# Altium schematic sheet MCU.SchDoc
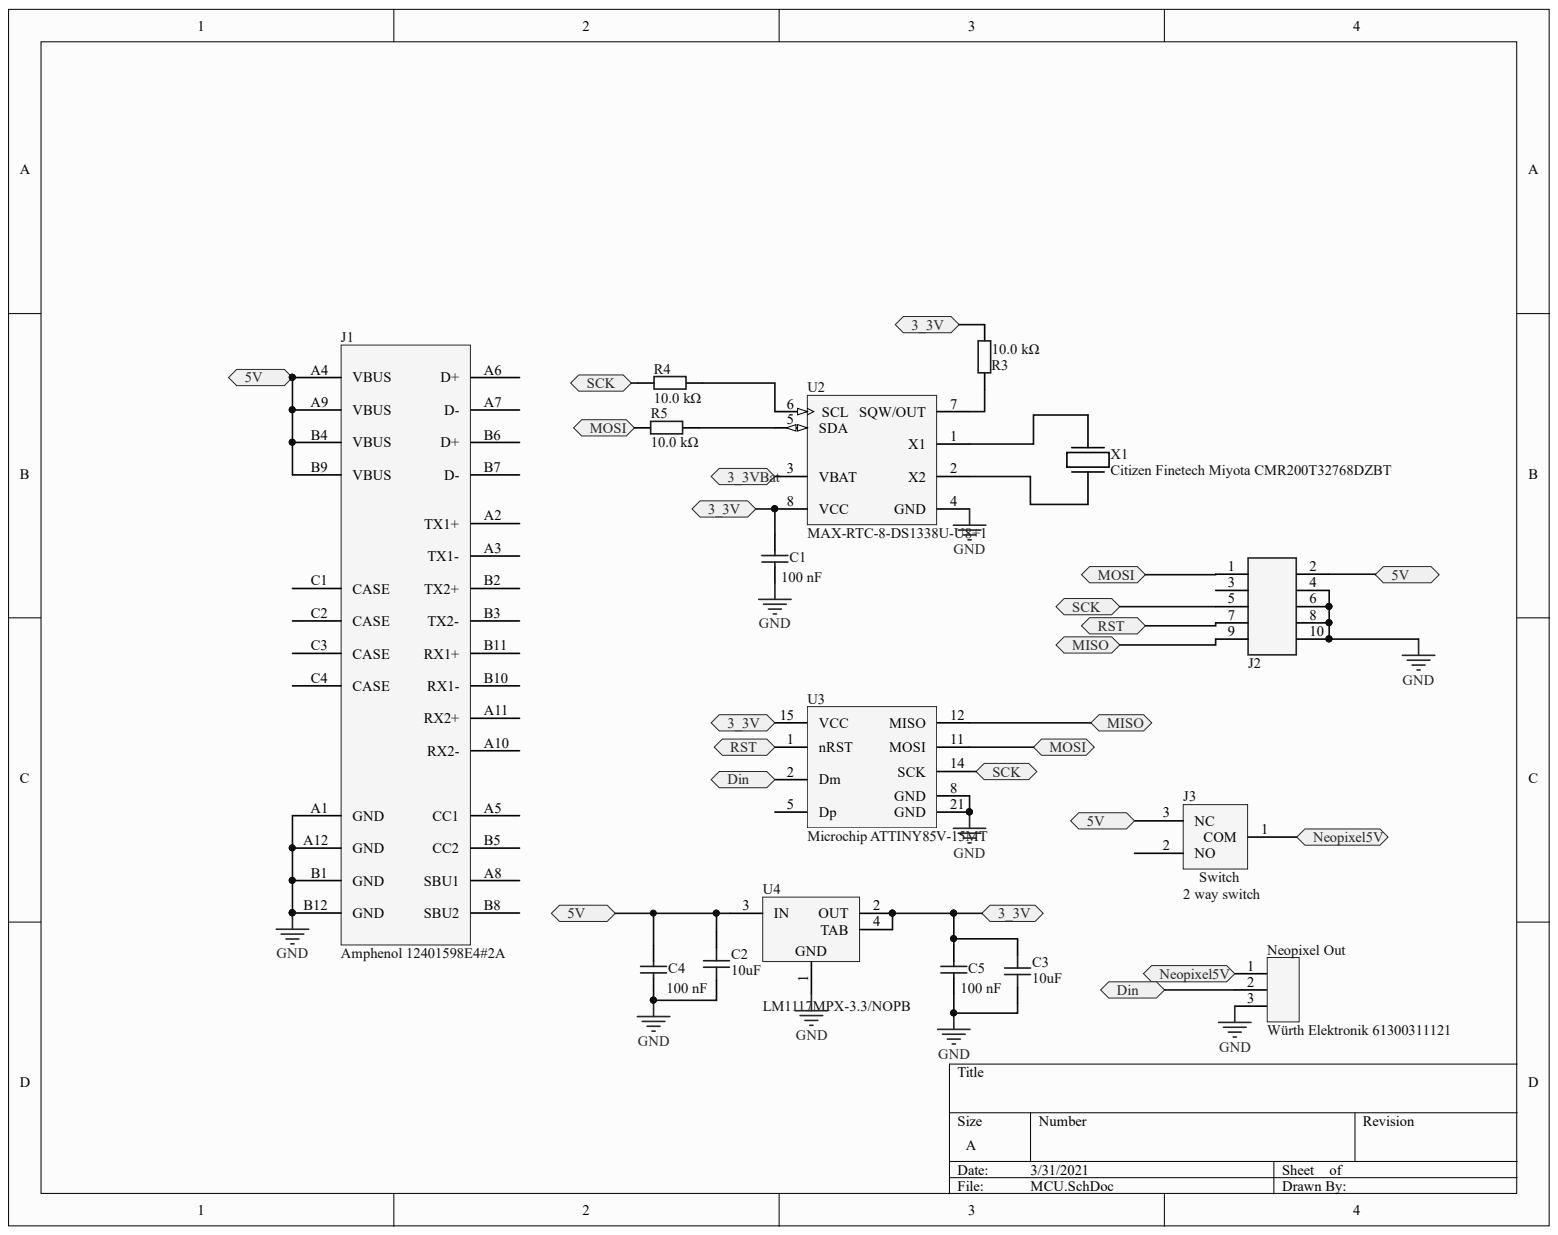
[diagram p0: MCU.SchDoc]

## Parts
C1  Capacitance: 100 nF  [PCB-eewx94glvk4bhx4pifal-1]  (hidden comment: CL05F104ZO5NNNC)
C2  10uF  [C0603]
C3  10uF  [C0603]
C4  CL05F104ZO5NNNC  [PCB-eewx94glvk4bhx4pifal-1]  (value not drawn)
C5  CL05F104ZO5NNNC  [PCB-eewx94glvk4bhx4pifal-1]  (value not drawn)
J1  Amphenol 12401598E4#2A  Manufacturer Part Number: 12401598E4#2A  [PCB-o51ryed2xyiiyzdqukpx-1]
J2  Connecteur_HE10_2X5  [PCB-vpkch8h1uqdxqvynfy4j-1]  (value not drawn)
J3  2 way switch  [PCB-z2mqghhu0j8lc0kix43w-1]
Neopixel_Out  Würth Elektronik 61300311121  [PCB-s0hfil65plaomi4ibvcw-1]
R3  Res2  [PCB-c90638nymr1sxtgb7n4t-1]  (value not drawn)
R4  Resistance: 10.0 kΩ  [PCB-c90638nymr1sxtgb7n4t-1]  (hidden comment: Res2)
R5  Resistance: 10.0 kΩ  [PCB-c90638nymr1sxtgb7n4t-1]  (hidden comment: Res2)
U2  MAX-RTC-8-DS1338U-U8+1  [U8+1_N]
U3  Microchip ATTINY85V-15MT  [PCBComponent_1 - duplicate]
U4  LM1117MPX-3.3/NOPB  [MP04A_L]
X1  Citizen Finetech Miyota CMR200T32768DZBT  [PCB-82rgjdkj2ta51ccq55iy-1]

## Ports
3_3V, 3_3VBAT, 5V, DIN, MISO, MOSI, NEOPIXEL5V, RST, SCK

## Nets
3_3VBAT: U2.3(VBAT) ; port 3_3VBAT
DIN: Neopixel_Out.2 U3.2(Dm) ; port DIN
GND: C1.1 C2.1 C3.1 C4.1 C5.1 J1.A1(GND) J1.A12(GND) J1.B1(GND) J1.B12(GND) J2.4 J2.6 J2.8 J2.10 Neopixel_Out.3 U2.4(GND) U3.8(GND) U3.21(GND) U4.1
NEOPIXEL5V: J3.1 Neopixel_Out.1 ; port NEOPIXEL5V
3_3V: C1.2 C3.2 C5.2 R3.2 U2.8(VCC) U3.15(VCC) U4.2(OUT) U4.4(TAB) ; port 3_3V
5V: C2.2 C4.2 J1.A4(VBUS) J1.A9(VBUS) J1.B4(VBUS) J1.B9(VBUS) J2.2 J3.3 U4.3(IN) ; port 5V
MISO: J2.9 U3.12(MISO) ; port MISO
MOSI: J2.1 R5.2 U3.11(MOSI) ; port MOSI
RST: J2.7 U3.1(nRST) ; port RST
SCK: J2.5 R4.2 U3.14(SCK) ; port SCK
NetR3_1: R3.1 U2.7(SQW/OUT)
NetR4_1: R4.1 U2.6(SCL)
NetR5_1: R5.1 U2.5(SDA)
NetU2_1: U2.1(X1) X1.2
NetU2_2: U2.2(X2) X1.1

Not connected: J1 A2(TX1+) A3(TX1-) A5(CC1) A6(D+) A7(D-) A8(SBU1) A10(RX2-) A11(RX2+) B2(TX2+) B3(TX2-) B5(CC2) B6(D+) B7(D-) B8(SBU2) B10(RX1-) B11(RX1+) C1(CASE) C2(CASE) C3(CASE) C4(CASE); J2 3; J3 2; U3 5(Dp)

## Library data of the parts
C1 = CMP-7737beb0b932a8f0-3: Cap Ceramic 0.1uF 16V Y5V -20% to 80% SMD 0402 85°C Paper T/R; Capacitance Tolerance: +80/-20%; Lead-Free Status: Lead Free; Voltage Rating (DC): 16.0 V; Case/Package: 0402; Component Kind: Standard; Dielectric Characteristic: Y5V; Library Name: CommunityVault; Manufacturer Part Number: CL05F104ZO5NNNC; OctopartID: 213ce849cbe4fefb; Octopart URL: https://octopart.com/cl05f104zo5nnnc-samsung-8376254; RoHS: Compliant
C2 C3 = CMP-2000ea615e44ffc7-5: Multilayer Ceramic Capacitors MLCC - SMD/SMT 0603 10uF 10volts *Derate Voltage/Temp; Manufacturer: Murata Electronics; Manufacturer Part Number: GRM188R61A106KE69J; Capacitance: 10.0 µF; Case/Package (SI): 1608; Dielectric Characteristic: X5R; Lead-Free Status: Lead Free; Voltage Rating (DC): 10.0 V; Case/Package: 0603, 1608; Category: Multilayer Ceramic Capacitors MLCC - SMD/SMT; Component Kind: Standard; Library Name: CommunityVault; Lifecycle Status: Active; OctopartID: 2000ea615e44ffc7; Octopart URL: https://octopart.com/grm188r61a106ke69j-murata-57367330; Package / Case: 0603 (1608 metric); RoHS: Compliant; Size-Thickness: 800 µm; Size-Width: 800 µm; Supplier 1: Mouser; Supplier Part Number 1: 81-GRM188R61A106KE9J
C4 C5 = CMP-7737beb0b932a8f0-3: Cap Ceramic 0.1uF 16V Y5V -20% to 80% SMD 0402 85°C Paper T/R; Capacitance: 100 nF; Capacitance Tolerance: +80/-20%; Lead-Free Status: Lead Free; Voltage Rating (DC): 16.0 V; Case/Package: 0402; Component Kind: Standard; Dielectric Characteristic: Y5V; Library Name: CommunityVault; Manufacturer Part Number: CL05F104ZO5NNNC; OctopartID: 213ce849cbe4fefb; Octopart URL: https://octopart.com/cl05f104zo5nnnc-samsung-8376254; RoHS: Compliant
J1 = CMP-1e79d211525dc1be-1: USB 3.1 Gen 2 Type C Receptacle Right Angle Top Mount Dual SMT 2.1mm Shell Tab Black Bulk; Component Kind: Standard; Library Name: CommunityVault; Mounting Style: Surface Mount; OctopartID: 1e79d211525dc1be; Octopart URL: https://octopart.com/12401598e4%232a-amphenol+icc-90335554; RoHS: Compliant
J2 = CMP-1d55d605c481c292-6: Header; Pin; Vertical; Copper Alloy; Thermoplastic; 10; 0.100 x 0.100 in.; 1 A; Manufacturer Part Number: 5103308-1; Current Rating: 3.00 A; ELV Compliant: Compliant; Gender: Male; Insulation Resistance: 5.00 GΩ; Lead-Free Status: Lead Free; Mounting Angle: 180 °; Number of Contacts: 10; Pin Pitch: 2.54 mm; Color: Black; Component Kind: Standard; Contact Material: Copper Alloy; Contact Plating: Gold Flash, Gold Flash over Nickel, Tin, Tin over Nickel; Flammability Rating: UL 94 V-0; Housing Color: Black; Housing Material: Thermoplastic; Library Name: CommunityVault; Lifecycle Status: Active; Material: Polyester; Mounting Style: Through Hole, Vertical; Number of Positions: 10; Number of Rows: 2; OctopartID: 1d55d605c481c292; Octopart URL: http://octopart.com/5103308-1-te+connectivity+%2F+amp-39517362; Orientation: Vertical; Packaging: Tube; REACH SVHC Compliance: No SVHC; RoHS: Compliant; Size-Height: 9.78 mm; Size-Length: 20.3 mm; Size-Width: 9.14 mm
J3 = CMP-94a55dc393914b62-14: C&k Components JS202011SCQN / Switch Slide DPDT 6VDC 0.3A SMT; Lead-Free Status: Lead Free; Component Kind: Standard; Contacts Type: DPDT; Library Name: CommunityVault; Manufacturer Part Number: JS202011SCQN; Mounting Style: Surface Mount; OctopartID: 94a55dc393914b62; Orientation: Vertical; Packaging: Reel; REACH SVHC Compliance: No SVHC; RoHS: Compliant
Neopixel_Out = CMP-8a90426ffa175557-31: THT Vertical Pin Header WR-PHD, Pitch 2.54 mm, Single Row, 3 pins; Current Rating: 3.00 A; Component Kind: Standard; Library Name: CommunityVault
R3 = CMP-494d8056daa7968a-26: Res Thick Film 0603 10K Ohm 5% 1/10W ±100ppm/°C Molded SMD SMD Paper T/R; Manufacturer Part Number: RC0603JR-0710KL; Case/Package (SI): 1608; Lead-Free Status: Lead Free; Power Rating: 100 mW; Resistance: 10.0 kΩ; Temperature Coefficient: -100 ppm/°C to 100 ppm/°C; Voltage Rating (DC): 75.0 V, 50.0 V; Case/Package: 0603; Component Kind: Standard; Library Name: CommunityVault; Mounting Style: Surface Mount; Number of Pins: 2; OctopartID: 494d8056daa7968a; Octopart URL: https://octopart.com/rc0603jr-0710kl-yageo-1160844; Operating Temperature: 155 °C (max); Packaging: Tape & Reel; REACH SVHC Compliance: No SVHC; Resistance Tolerance: ±5%; RoHS: Compliant; Size-Height: 450 µm; Size-Length: 1.60 mm; Size-Width: 800 µm
R4 R5 = CMP-494d8056daa7968a-26: Res Thick Film 0603 10K Ohm 5% 1/10W ±100ppm/°C Molded SMD SMD Paper T/R; Manufacturer Part Number: RC0603JR-0710KL; Case/Package (SI): 1608; Lead-Free Status: Lead Free; Power Rating: 100 mW; Temperature Coefficient: -100 ppm/°C to 100 ppm/°C; Voltage Rating (DC): 75.0 V, 50.0 V; Case/Package: 0603; Component Kind: Standard; Library Name: CommunityVault; Mounting Style: Surface Mount; Number of Pins: 2; OctopartID: 494d8056daa7968a; Octopart URL: https://octopart.com/rc0603jr-0710kl-yageo-1160844; Operating Temperature: 155 °C (max); Packaging: Tape & Reel; REACH SVHC Compliance: No SVHC; Resistance Tolerance: ±5%; RoHS: Compliant; Size-Height: 450 µm; Size-Length: 1.60 mm; Size-Width: 800 µm
U2 = CMP-1020999-3: I2C Real-Time Clock with 56-Byte NV RAM, 1.8V, 8-Pin uSOP, -40 to 85 degC, Pb-Free; Manufacturer Part Number: DS1338U-18+; Lead-Free Status: Lead Free; Component Kind: Standard; Library Name: CommunityVault; Lifecycle Status: Not Listed by Manufacturer; OctopartID: fbe83243ff1d242f; Octopart Url: https://octopart.com/ds1338u-18%2B-maxim+integrated-10559891
U3 = CMP-1299df31b8dbcd5e-1: MCU 8-bit ATtiny AVR RISC 8KB Flash 3V/5V 20-Pin VQFN Tube; Component Kind: Standard; Library Name: CommunityVault; Mounting Style: Surface Mount; Octopart URL: https://octopart.com/attiny85v-15mt-microchip-77762340
U4 = CMP-0062-01639-6: 800mA Low-Dropout Linear Regulator, 4-pin SOT-223, Pb-Free; Component Kind: Standard; Library Name: CommunityVault
X1 = CMP-88ce42ed91805709-1: CMR200T Series 32.768 kHz ±20 ppm 6 pF -40 to +85 °C SMT Tuning Fork Crystal; Equivalent Series Resistance (ESR): 50.0 kΩ; Lead-Free Status: Lead Free; Load Capacitance: 6.00 pF; Component Kind: Standard; Library Name: CommunityVault; Manufacturer Part Number: CMR200T32768DZBT; OctopartID: 88ce42ed91805709; Octopart URL: http://octopart.com/cmr200t32768dzbt-citizen+finetech+miyota-53034024; RoHS: Compliant
